# Altium schematic sheet MCS_diag_uC.SchDoc(MCS_diag_uC)
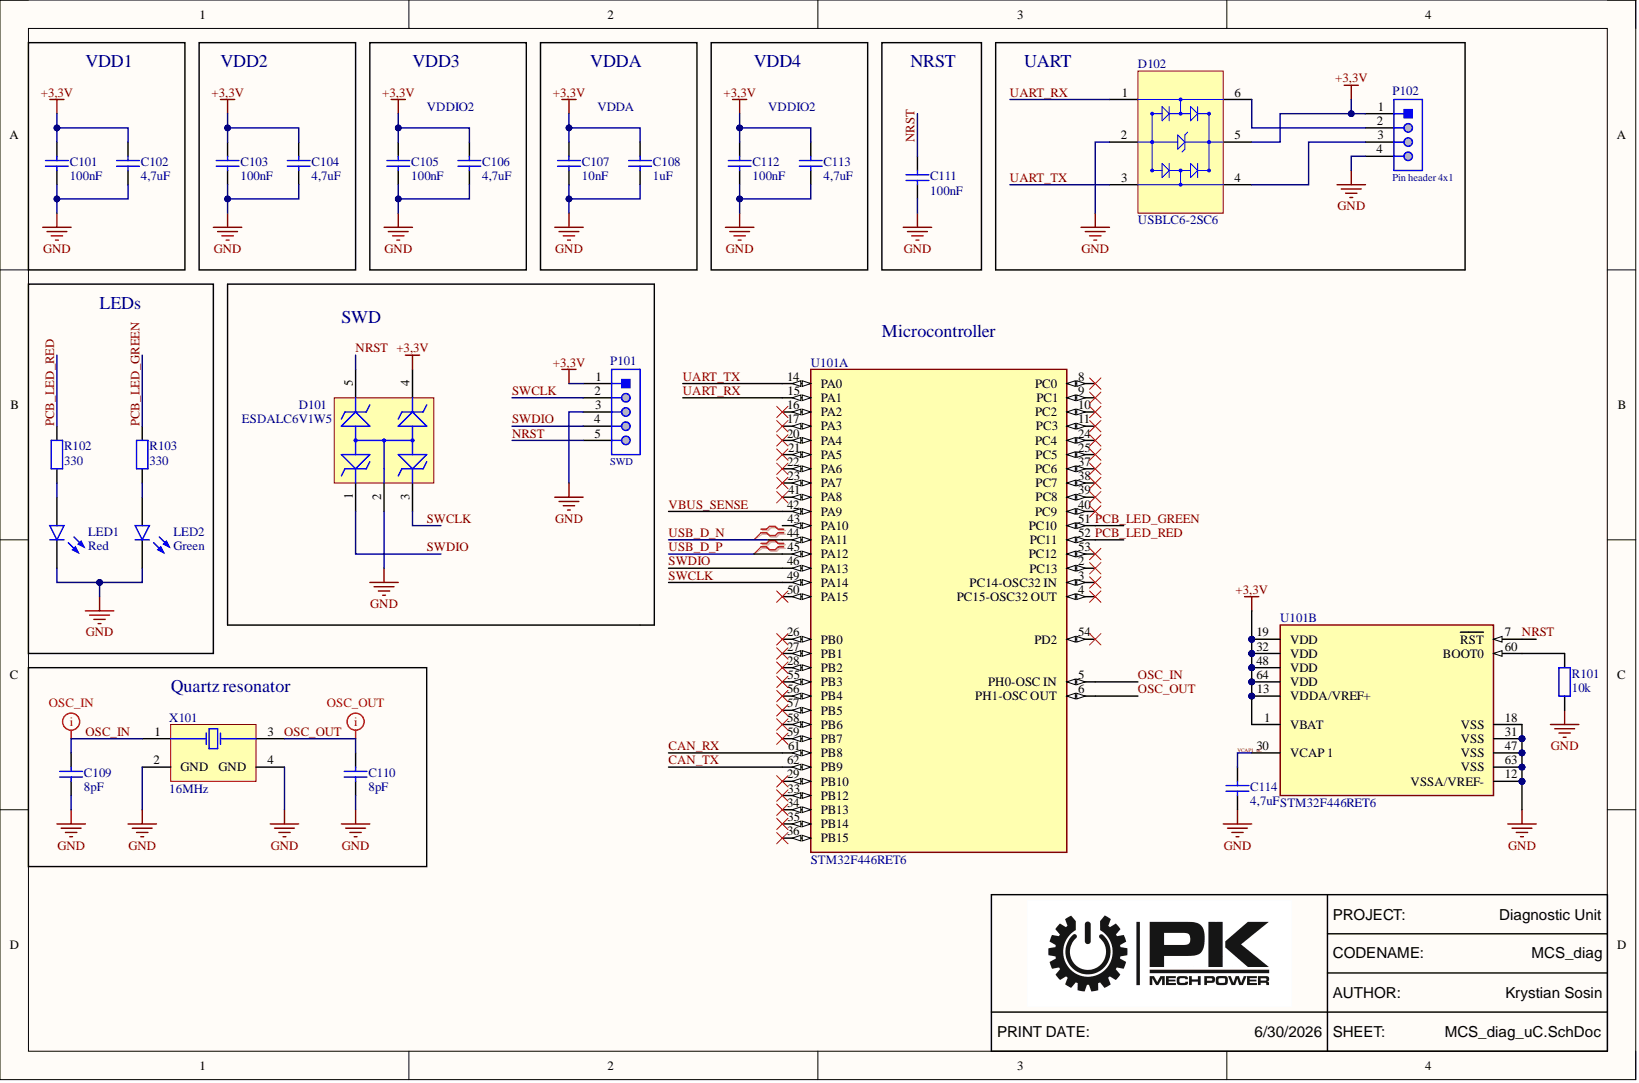
[diagram p0: MCS_diag_uC.SchDoc]

## Parts
C101  value 100nF  (hidden comment: Capacitor 0603)
C102  value 4,7uF  (hidden comment: Capacitor 0603)
C103  value 100nF  (hidden comment: Capacitor 0603)
C104  value 4,7uF  (hidden comment: Capacitor 0603)
C105  value 100nF  (hidden comment: Capacitor 0603)
C106  value 4,7uF  (hidden comment: Capacitor 0603)
C107  value 10nF  (hidden comment: Capacitor 0603)
C108  value 1uF  (hidden comment: Capacitor 0603)
C109  value 8pF  (hidden comment: Capacitor 0603)
C110  value 8pF  (hidden comment: Capacitor 0603)
C111  value 100nF  (hidden comment: Capacitor 0603)
C112  value 100nF  (hidden comment: Capacitor 0603)
C113  value 4,7uF  (hidden comment: Capacitor 0603)
C114  value 4,7uF  (hidden comment: Capacitor 0603)
D101  ESDALC6V1W5
D102  USBLC6-2SC6
LED1  Red
LED2  Green
P101  SWD
P102  Pin header 4x1
R101  value 10k  (hidden comment: Resistor 0603)
R102  value 330  (hidden comment: Resistor 0603)
R103  value 330  (hidden comment: Resistor 0603)
U101  STM32F446RET6  (parts A,B)
X101  16MHz

## Nets
+3,3V: C101.1 C102.1 C103.1 C104.1 C105.1 C106.1 C107.1 C108.1 C112.1 C113.1 D101.4 D102.5 P101.1 P102.1 U101.1(VBAT) U101.13(VDDA/VREF+) U101.19(VDD) U101.32(VDD) U101.48(VDD) U101.64(VDD)
GND: C101.2 C102.2 C103.2 C104.2 C105.2 C106.2 C107.2 C108.2 C109.1 C110.1 C111.2 C112.2 C113.2 C114.1 D101.2 D102.2 LED1.1 LED2.1 P101.3 P102.4 R101.1 U101.12(VSSA/VREF-) U101.18(VSS) U101.31(VSS) U101.47(VSS) U101.63(VSS) X101.2(GND) X101.4(GND)
CAN_RX: U101.61(PB8)
CAN_TX: U101.62(PB9)
NRST: C111.1 D101.5 P101.5 U101.7(RST)
OSC_IN: C109.2 U101.5(PH0-OSC_IN) X101.1
OSC_OUT: C110.2 U101.6(PH1-OSC_OUT) X101.3
PCB_LED_GREEN: R103.2 U101.51(PC10)
PCB_LED_RED: R102.2 U101.52(PC11)
SWCLK: D101.3 P101.2 U101.49(PA14)
SWDIO: D101.1 P101.4 U101.46(PA13)
UART_RX: D102.1 U101.15(PA1)
UART_TX: D102.3 U101.14(PA0)
USB_D_N: U101.44(PA11)
USB_D_P: U101.45(PA12)
VBUS_SENSE: U101.42(PA9)
VCAP1_uC: C114.2 U101.30(VCAP_1)
NetD102_4: D102.4 P102.3
NetD102_6: D102.6 P102.2
NetLED1_2: LED1.2 R102.1
NetLED2_2: LED2.2 R103.1
NetR101_2: R101.2 U101.60(BOOT0)

Not connected: U101 2(PC13) 3(PC14-OSC32_IN) 4(PC15-OSC32_OUT) 8(PC0) 9(PC1) 10(PC2) 11(PC3) 16(PA2) 17(PA3) 20(PA4) 21(PA5) 22(PA6) 23(PA7) 24(PC4) 25(PC5) 26(PB0) 27(PB1) 28(PB2) 29(PB10) 33(PB12) 34(PB13) 35(PB14) 36(PB15) 37(PC6) 38(PC7) 39(PC8) 40(PC9) 41(PA8) 43(PA10) 50(PA15) 53(PC12) 54(PD2) 55(PB3) 56(PB4) 57(PB5) 58(PB6) 59(PB7)

## Library data of the parts
C101-C113 = CMP-001-00008-3: SMD 0603 capacitor; Component Kind: Standard; Library Name: EMEA- PK MechPower-  Cracow University of Technology
C114 = CMP-001-00008-4: SMD 0603 capacitor; Component Kind: Standard; Library Name: EMEA- PK MechPower-  Cracow University of Technology
D101 = CMP-004-00022-3: TVS DIODE 3VWM SOT3235; Component Kind: Standard; Library Name: EMEA- PK MechPower-  Cracow University of Technology
D102 = CMP-004-00011-2: USBLC6 Series 2 Line 6 V Uni / Bi-Directional ESD Protection - SOT-23-6; Component Kind: Standard; Library Name: EMEA- PK MechPower-  Cracow University of Technology
LED1 LED2 = CMP-007-00000-4: SMD 0805 LED; Component Kind: Standard; Library Name: EMEA- PK MechPower-  Cracow University of Technology
P101 = CMP-1502-01068-1: THT Vertical Pin Header WR-PHD, Pitch 2.54 mm, Single Row, 5 pins; Manufacturer: Wurth Elektronik; Part Number: 61300511121; Contact Resistance: 20mOhm; Gender: Male; Pin Number: 5; Pitch: 2.54mm; Rated Current: 3A; Withstanding Voltage: 500VAC; Working Voltage: 250VAC; Component Kind: Standard; Datasheet URL: https://katalog.we-online.de/em/datasheet/6130xx11121.pdf; Library Name: Altium Content Vault; Manufacturer URL: http://www.we-online.de; Mounting Technology: THT; Operating Temperature: -40to105degC; Orientation: Vertical; Package Reference: 61300511121; Packaging: Bag; Row Number: Single; Publisher: WurthElektronik
P102 = CMP-00001-2: THT Vertical Pin Header, Pitch 2.54 mm, Single Row, 4 pins; Component Kind: Standard; Library Name: EMEA- PK MechPower-  Cracow University of Technology
R101-R103 = CMP-009-00010-3: SMD 0603 resistor; Component Kind: Standard; Library Name: EMEA- PK MechPower-  Cracow University of Technology
U101 = CMP-020-00001-4: MCU 32-bit ARM Cortex M4 RISC 512KB Flash 2.5V/3.3V Medical 64-Pin LQFP Frame; Component Kind: Standard; Library Name: EMEA- PK MechPower-  Cracow University of Technology
X101 = CMP-003-00030-2: SR PASSIVES 16MHz, ±10ppm, 9pF, SMD 3,2x2,5x0,7mm, 3225-16M-SR; Component Kind: Standard; Library Name: EMEA- PK MechPower-  Cracow University of Technology
